# Altium schematic sheet switches.SchDoc
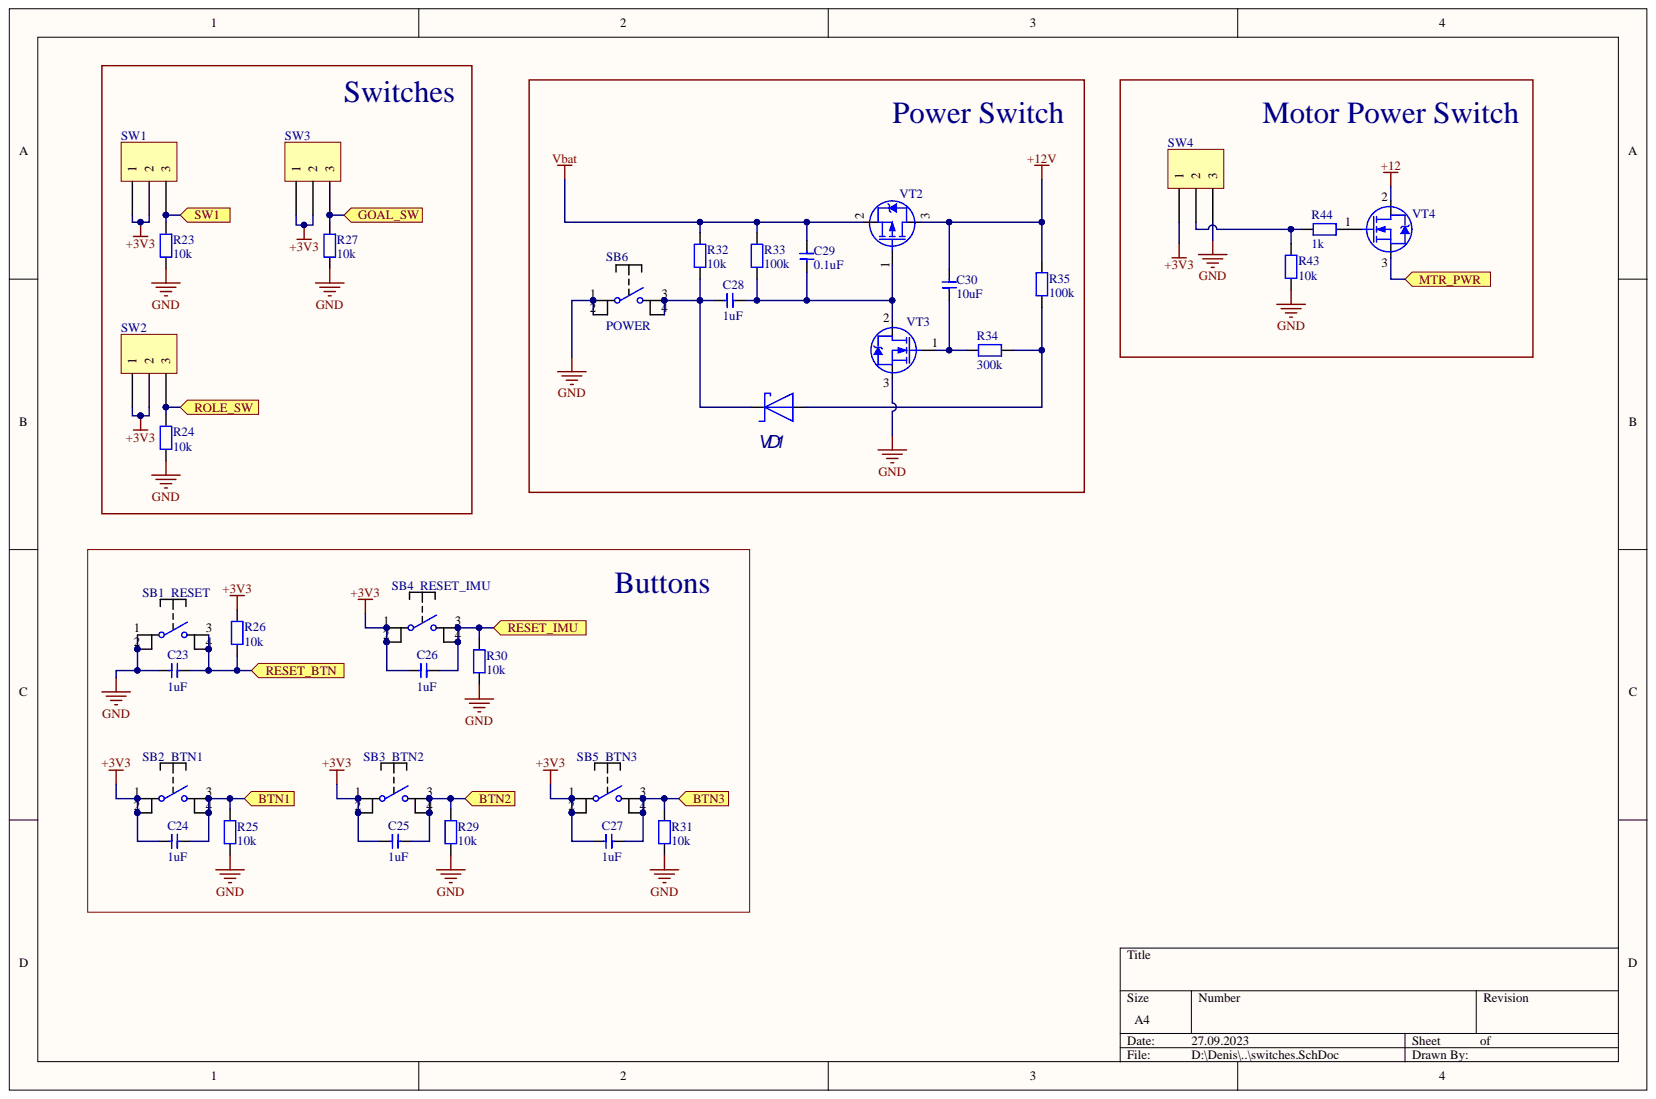
[diagram p0: switches.SchDoc]

## Parts
C23  1uF  [cap0603]
C24  1uF  [cap0603]
C25  1uF  [cap0603]
C26  1uF  [cap0603]
C27  1uF  [cap0603]
C28  1uF  [cap0603]
C29  0.1uF  [cap0603]
C30  10uF  [cap0603]
R23  10k  [res0603]
R24  10k  [res0603]
R25  10k  [res0603]
R26  10k  [res0603]
R27  10k  [res0603]
R29  10k  [res0603]
R30  10k  [res0603]
R31  10k  [res0603]
R32  10k  [res0603]
R33  100k  [res0603]
R34  300k  [res0603]
R35  100k  [res0603]
R43  10k  [res0603]
R44  1k  [res0603]
SB1  RESET  [TL3700AF160QG]
SB2  BTN1  [TL3700AF160QG]
SB3  BTN2  [TL3700AF160QG]
SB4  RESET_IMU  [TL3700AF160QG]
SB5  BTN3  [TL3700AF160QG]
SB6  POWER  [TL3700AF160QG]
SW1  ESP1010  [ESP1010]  (value not drawn)
SW2  ESP1010  [ESP1010]  (value not drawn)
SW3  ESP1010  [ESP1010]  (value not drawn)
SW4  ESP1010  [ESP1010]  (value not drawn)
VD1  (no value)  [SOD123]
VT2  P-Channel MOSFET  [TO252AA]  (value not drawn)
VT3  N-Channel Mosfet  [TO252AA]  (value not drawn)
VT4  N-Channel Mosfet  [TO252AA]  (value not drawn)

## Ports
BTN1, BTN2, BTN3, GOAL_SW, MTR_PWR, RESET_BTN, RESET_IMU, ROLE_SW, SW1

## Nets
+3V3: C24.1 C25.1 C26.1 C27.1 R26.2 SB2.1 SB2.2 SB3.1 SB3.2 SB4.1 SB4.2 SB5.1 SB5.2 SW1.1 SW1.2 SW2.1 SW2.2 SW3.1 SW3.2 SW4.1
+12: VT4.2
+12V: C30.2 R35.2 VT2.3
GND: C23.1 R23.2 R24.2 R25.1 R27.2 R29.1 R30.1 R31.1 R43.1 SB1.1 SB1.2 SB6.1 SB6.2 SW4.3 VT3.3
Vbat: C29.2 R32.2 R33.2 VT2.2
BTN1: C24.2 R25.2 SB2.3 SB2.4 ; port BTN1
BTN2: C25.2 R29.2 SB3.3 SB3.4 ; port BTN2
BTN3: C27.2 R31.2 SB5.3 SB5.4 ; port BTN3
GOAL_SW: R27.1 SW3.3 ; port GOAL_SW
MTR_PWR: VT4.3 ; port MTR_PWR
RESET_BTN: C23.2 R26.1 SB1.3 SB1.4 ; port RESET_BTN
RESET_IMU: C26.2 R30.2 SB4.3 SB4.4 ; port RESET_IMU
ROLE_SW: R24.1 SW2.3 ; port ROLE_SW
SW1: R23.1 SW1.3 ; port SW1
NetC28_1: C28.1 R32.1 SB6.3 SB6.4 VD1.1
NetC28_2: C28.2 C29.1 R33.1 VT2.1 VT3.2
NetC30_1: C30.1 R34.2 VT3.1
NetR34_1: R34.1 R35.1 VD1.2
NetR43_2: R43.2 R44.2 SW4.2
NetR44_1: R44.1 VT4.1

## Library data of the parts
C23-C30 = Cap SMD: Component Kind: Standard; Library Name: GKirik.SchLib
R23-R27 R29-R35 R43 R44 = Resistor SMD: Component Kind: Standard; Library Name: GKirik.SchLib
SB1-SB6 = TL3700AF160QG: SWITCH TACTILE SPST-NO 0.02A 15V; Database Table Name: Buttons; Component Kind: Standard; Digi-Key Part Number: EG4919CT-ND; HelpURL: https://www.digikey.com/product-detail/en/e-switch/TL3700AF160QG/EG4919CT-ND/2538592; Part Number: TL3700AF160QG; Library Name: SssAccesDatbase.DbLib
VD1 = DiodeSchottky: Диод Шоттки; Component Kind: Standard; Library Name: GKirik.SchLib
VT2 = IRFR9024N: Database Table Name: Transistor; Component Kind: Standard; Part Number: IRFR9024N; Library Name: SssAccesDatbase.DbLib
VT3 VT4 = IRFR2405: DPAK; Database Table Name: Transistor; Component Kind: Standard; Part Number: IRFR2405; Library Name: SssAccesDatbase.DbLib
SW1-SW4 = ESP1010: Component Kind: Standard; Library Name: GKirik.SchLib
